# Altium schematic sheet BLDC.SchDoc
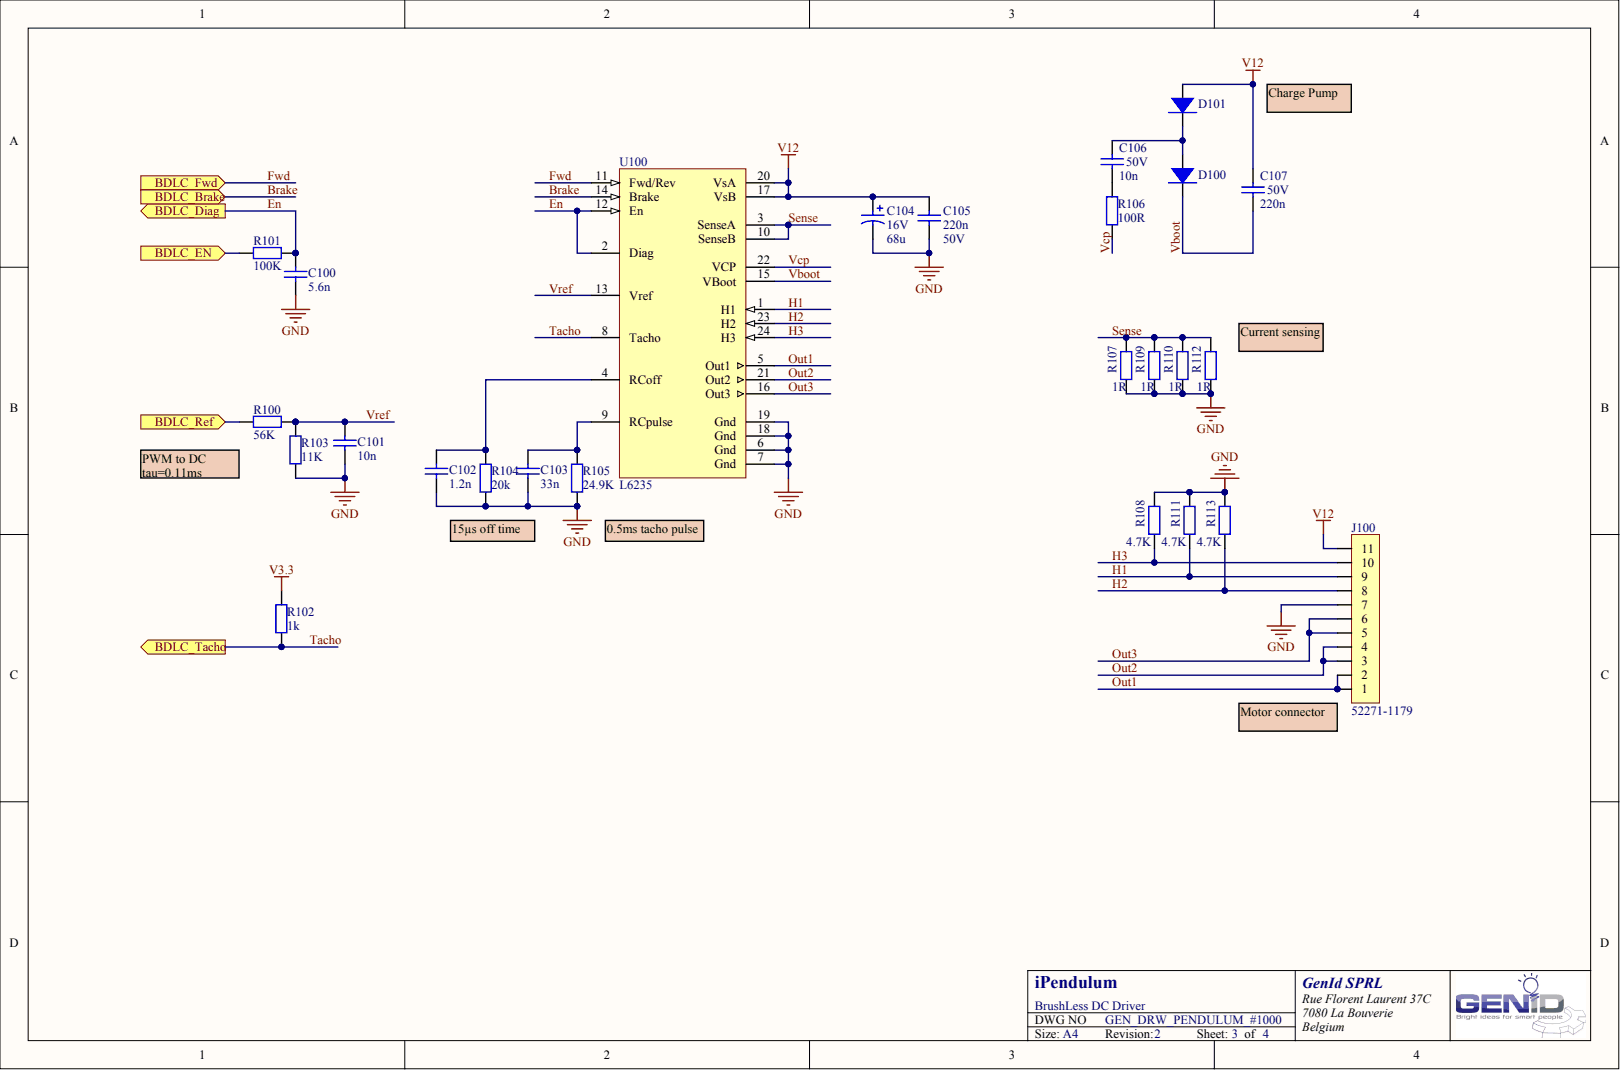
[diagram p0: BLDC.SchDoc]

## Parts
C100  value 5.6n  [0805-CAP]
C101  value 10n  [0805-CAP]
C102  value 1.2n  [0805-CAP]
C103  value 33n  [0805-CAP]
C104  value 68u  Voltage: 16V  [SMD KEMET D]
C105  value 220n  Voltage: 50V  [0805-CAP]
C106  value 10n  Voltage: 50V  [0805-CAP]
C107  value 220n  Voltage: 50V  [0805-CAP]
D100  (no value)  [SOD-123]
D101  (no value)  [SOD-123]
J100  Reference: 52271-1179  [FPC_11x1_Bottom]  (hidden comment: FPC-11x1-52271-1179)
R100  value 56K  [SMD 0805]
R101  value 100K  [SMD 0805]
R102  value 1k  [SMD 0805]
R103  value 11K  [SMD 0805]
R104  value 20k  [SMD 0805]
R105  value 24.9K  [SMD 0805]
R106  value 100R  [SMD 1206]
R107  value 1R  [SMD 1206]
R108  value 4.7K  [SMD 0805]  (hidden comment: CRCW08054K70FKEA)
R109  value 1R  [SMD 1206]
R110  value 1R  [SMD 1206]
R111  value 4.7K  [SMD 0805]  (hidden comment: CRCW08054K70FKEA)
R112  value 1R  [SMD 1206]
R113  value 4.7K  [SMD 0805]  (hidden comment: CRCW08054K70FKEA)
U100  (no value)  [POWSO_36_Hole]

## Ports
BDLC_BRAKE, BDLC_DIAG, BDLC_EN, BDLC_FWD, BDLC_REF, BDLC_TACHO

## Nets
GND: C100.2 C101.2 C102.2 C103.2 C104.2 C105.2 J100.7 R103.1 R104.1 R105.1 R107.1 R108.2 R109.1 R110.1 R111.2 R112.1 R113.2 U100.6(Gnd) U100.7(Gnd) U100.18(Gnd) U100.19(Gnd)
V3.3: R102.2
V12: C104.1 C105.1 C107.1 D101.1 J100.11 U100.17(VsB) U100.20(VsA)
BDLC_EN: R101.2 ; port BDLC_EN
BRAKE: U100.14(Brake) ; port BDLC_BRAKE
EN: C100.1 R101.1 U100.2(Diag) U100.12(En) ; port BDLC_DIAG
FWD: U100.11(Fwd/Rev) ; port BDLC_FWD
H1: J100.9 R111.1 U100.1(H1)
H2: J100.8 R113.1 U100.23(H2)
H3: J100.10 R108.1 U100.24(H3)
OUT1: J100.1 J100.2 U100.5(Out1)
OUT2: J100.3 J100.4 U100.21(Out2)
OUT3: J100.5 J100.6 U100.16(Out3)
SENSE: R107.2 R109.2 R110.2 R112.2 U100.3(SenseA) U100.10(SenseB)
TACHO: R102.1 U100.8(Tacho) ; port BDLC_TACHO
VBOOT: C107.2 D100.2 U100.15(VBoot)
VCP: R106.1 U100.22(VCP)
VREF: C101.1 R100.2 R103.2 U100.13(Vref)
NetC102_1: C102.1 R104.2 U100.4(RCoff)
NetC103_1: C103.1 R105.2 U100.9(RCpulse)
NetC106_1: C106.1 D100.1 D101.2
NetC106_2: C106.2 R106.2
NetR100_1: R100.1 ; port BDLC_REF

## Library data of the parts
C100 = C0805C562K5RA: 5.6n-0805-50V-10%-X7R; Manufacturer: Kemet; Dielectric: X7R; Tolerance: 10%; Voltage: 50V; Component Kind: Standard; HelpURL: http://www.kemet.com/kemet/web/homepage/kechome.nsf/weben/DC9E4F3CDBEF8272852579290047C2CA/$file/KEM_CC101_COMM_SMD.pdf; Library Name: libCapacitors.IntLib; Reference: C0805C562K5RA
C101 = C0805C103K5RA: 10n-0805-50V-10%-X7R; Manufacturer: Kemet; Dielectric: X7R; Tolerance: 10%; Voltage: 50V; Component Kind: Standard; HelpURL: http://www.kemet.com/kemet/web/homepage/kechome.nsf/weben/DC9E4F3CDBEF8272852579290047C2CA/$file/KEM_CC101_COMM_SMD.pdf; Library Name: libCapacitors.IntLib; Reference: C0805C103K5RA
C102 = C0805C122K5RA: 1.2n-0805-50V-10%-X7R; Manufacturer: Kemet; Dielectric: X7R; Tolerance: 10%; Voltage: 50V; Component Kind: Standard; HelpURL: http://www.kemet.com/kemet/web/homepage/kechome.nsf/weben/DC9E4F3CDBEF8272852579290047C2CA/$file/KEM_CC101_COMM_SMD.pdf; Library Name: libCapacitors.IntLib; Reference: C0805C122K5RA
C103 = C0805C333K5RA: 33n-0805-50V-10%-X7R; Manufacturer: Kemet; Dielectric: X7R; Tolerance: 10%; Voltage: 50V; Component Kind: Standard; HelpURL: http://www.kemet.com/kemet/web/homepage/kechome.nsf/weben/DC9E4F3CDBEF8272852579290047C2CA/$file/KEM_CC101_COMM_SMD.pdf; Library Name: libCapacitors.IntLib; Reference: C0805C333K5RA
C104 = T520D686M016ATE050: 68u-16V-20%-0.050R; Manufacturer: Kemet; ESR: 0.050; Max Ripple Current: 2.1A; Tolerance: 20%; Component Kind: Standard; HelpURL: http://www.kemet.com/Lists/ProductCatalog/Attachments/421/KEM_T2015_T520.pdf; Library Name: libCapacitors.IntLib; Reference: T520D686M016ATE050
C105 C107 = C0805C224K5RA: 220n-0805-50V-10%-X7R; Manufacturer: Kemet; Dielectric: X7R; Tolerance: 10%; Component Kind: Standard; HelpURL: http://www.kemet.com/kemet/web/homepage/kechome.nsf/weben/DC9E4F3CDBEF8272852579290047C2CA/$file/KEM_CC101_COMM_SMD.pdf; Library Name: libCapacitors.IntLib; Reference: C0805C224K5RA
C106 = C0805C103K5RA: 10n-0805-50V-10%-X7R; Manufacturer: Kemet; Dielectric: X7R; Tolerance: 10%; Component Kind: Standard; HelpURL: http://www.kemet.com/kemet/web/homepage/kechome.nsf/weben/DC9E4F3CDBEF8272852579290047C2CA/$file/KEM_CC101_COMM_SMD.pdf; Library Name: libCapacitors.IntLib; Reference: C0805C103K5RA
D100 D101 = 1N4148W: SURFACE MOUNT FAST SWITCHING DIODE; Manufacturer: Diodes Incorporated; Imax: 300mA; Power: 400mW; Vmax: 100V; Component Kind: Standard; HelpURL: http://www.diodes.com/datasheets/ds30086.pdf; Library Name: libDiodes.IntLib; Reference: 1N4148W
J100 = FPC-11x1-52271-1179: 11 way, 1.00mm Pitch FFC/FPC Connector, Right Angle, Contact Bottom, SMT, ZIF; Manufacturer: Molex; Component Kind: Standard; HelpURL: http://www.molex.com/molex/products/datasheet.jsp?part=active/0522071185_FFC_FPC_CONNECTORS.xml&channel=Products&Lang=en-US; Library Name: libConnectors.IntLib
R100 = CRCW080556K0FKEA: 56K-0805-0.125W-1%-100ppm; Manufacturer: Vishay; Power: 1/8W; T. Coeff: 100ppm; Tolerance: 1%; Vmax: 150V; Component Kind: Standard; HelpURL: http://www.vishay.com/docs/20035/dcrcwe3.pdf; Library Name: libResistor.IntLib; Reference: CRCW080556K0FKEA
R101 = CRCW0805100KFKEA: 100K-0805-0.125W-1%-100ppm; Manufacturer: Vishay; Power: 1/8W; T. Coeff: 100ppm; Tolerance: 1%; Vmax: 150V; Component Kind: Standard; HelpURL: http://www.vishay.com/docs/20035/dcrcwe3.pdf; Library Name: libResistor.IntLib; Reference: CRCW0805100KFKEA
R102 = CRCW08051K00FKEA: 1K-0805-0.125W-1%-100ppm; Manufacturer: Vishay; Power: 1/8W; T. Coeff: 100ppm; Tolerance: 1%; Vmax: 150V; Component Kind: Standard; HelpURL: http://www.vishay.com/docs/20035/dcrcwe3.pdf; Library Name: libResistor.IntLib; Reference: CRCW08051K00FKEA
R103 = CRCW080511K0FKEA: 11K-0805-0.125W-1%-100ppm; Manufacturer: Vishay; Power: 1/8W; T. Coeff: 100ppm; Tolerance: 1%; Vmax: 150V; Component Kind: Standard; HelpURL: http://www.vishay.com/docs/20035/dcrcwe3.pdf; Library Name: libResistor.IntLib; Reference: CRCW080511K0FKEA
R104 = CRCW080520K0FKEA: 20K-0805-0.125W-1%-100ppm; Manufacturer: Vishay; Power: 1/8W; T. Coeff: 100ppm; Tolerance: 1%; Vmax: 150V; Component Kind: Standard; HelpURL: http://www.vishay.com/docs/20035/dcrcwe3.pdf; Library Name: libResistor.IntLib; Reference: CRCW080520K0FKEA
R105 = CRCW080524K9FKEA: 24.9K-0805-0.125W-1%-100ppm; Manufacturer: Vishay; Power: 1/8W; T. Coeff: 100ppm; Tolerance: 1%; Vmax: 150V; Component Kind: Standard; HelpURL: http://www.vishay.com/docs/20035/dcrcwe3.pdf; Library Name: libResistor.IntLib; Reference: CRCW080524K9FKEA
R106 = CRCW1206100RFKEA: 100R-1206-0.25W-1%-100ppm; Manufacturer: Vishay; Power: 1/4W; T. Coeff: 100ppm; Tolerance: 1%; Vmax: 200V; Component Kind: Standard; HelpURL: http://www.vishay.com/docs/20035/dcrcwe3.pdf; Library Name: libResistor.IntLib; Reference: CRCW1206100RFKEA
R107 R109 R110 R112 = CRCW12061R00FKEA: 1R-1206-0.25W-1%-100ppm; Manufacturer: Vishay; Power: 1/4W; T. Coeff: 100ppm; Tolerance: 1%; Vmax: 200V; Component Kind: Standard; HelpURL: http://www.vishay.com/docs/20035/dcrcwe3.pdf; Library Name: libResistor.IntLib; Reference: CRCW12061R00FKEA
R108 R111 R113 = CRCW08054K70FKEA: 4.7K-0805-0.125W-1%-100ppm; Manufacturer: Vishay; Power: 1/8W; T. Coeff: 100ppm; Tolerance: 1%; Vmax: 150V; Component Kind: Standard; HelpURL: http://www.vishay.com/docs/20035/dcrcwe3.pdf; Library Name: libResistor.IntLib; Reference: CRCW08054K70FKEA
U100 = L6235: DMOS driver for 3-phase brushless DC motor; Manufacturer: ST; Component Kind: Standard; ComponentLink1Description: Manufacturer Link; ComponentLink1URL: http://www.st.com/web/catalog/sense_power/FM142/CL851/SC1791/SS1157/LN1720/PF63244; ComponentLink2Description: Datasheet; ComponentLink2URL: http://www.st.com/st-web-ui/static/active/en/resource/technical/document/datasheet/CD00002347.pdf; Library Name: libIC-Interfaces.IntLib; Reference: L6235
